# Altium schematic sheet main.SchDoc
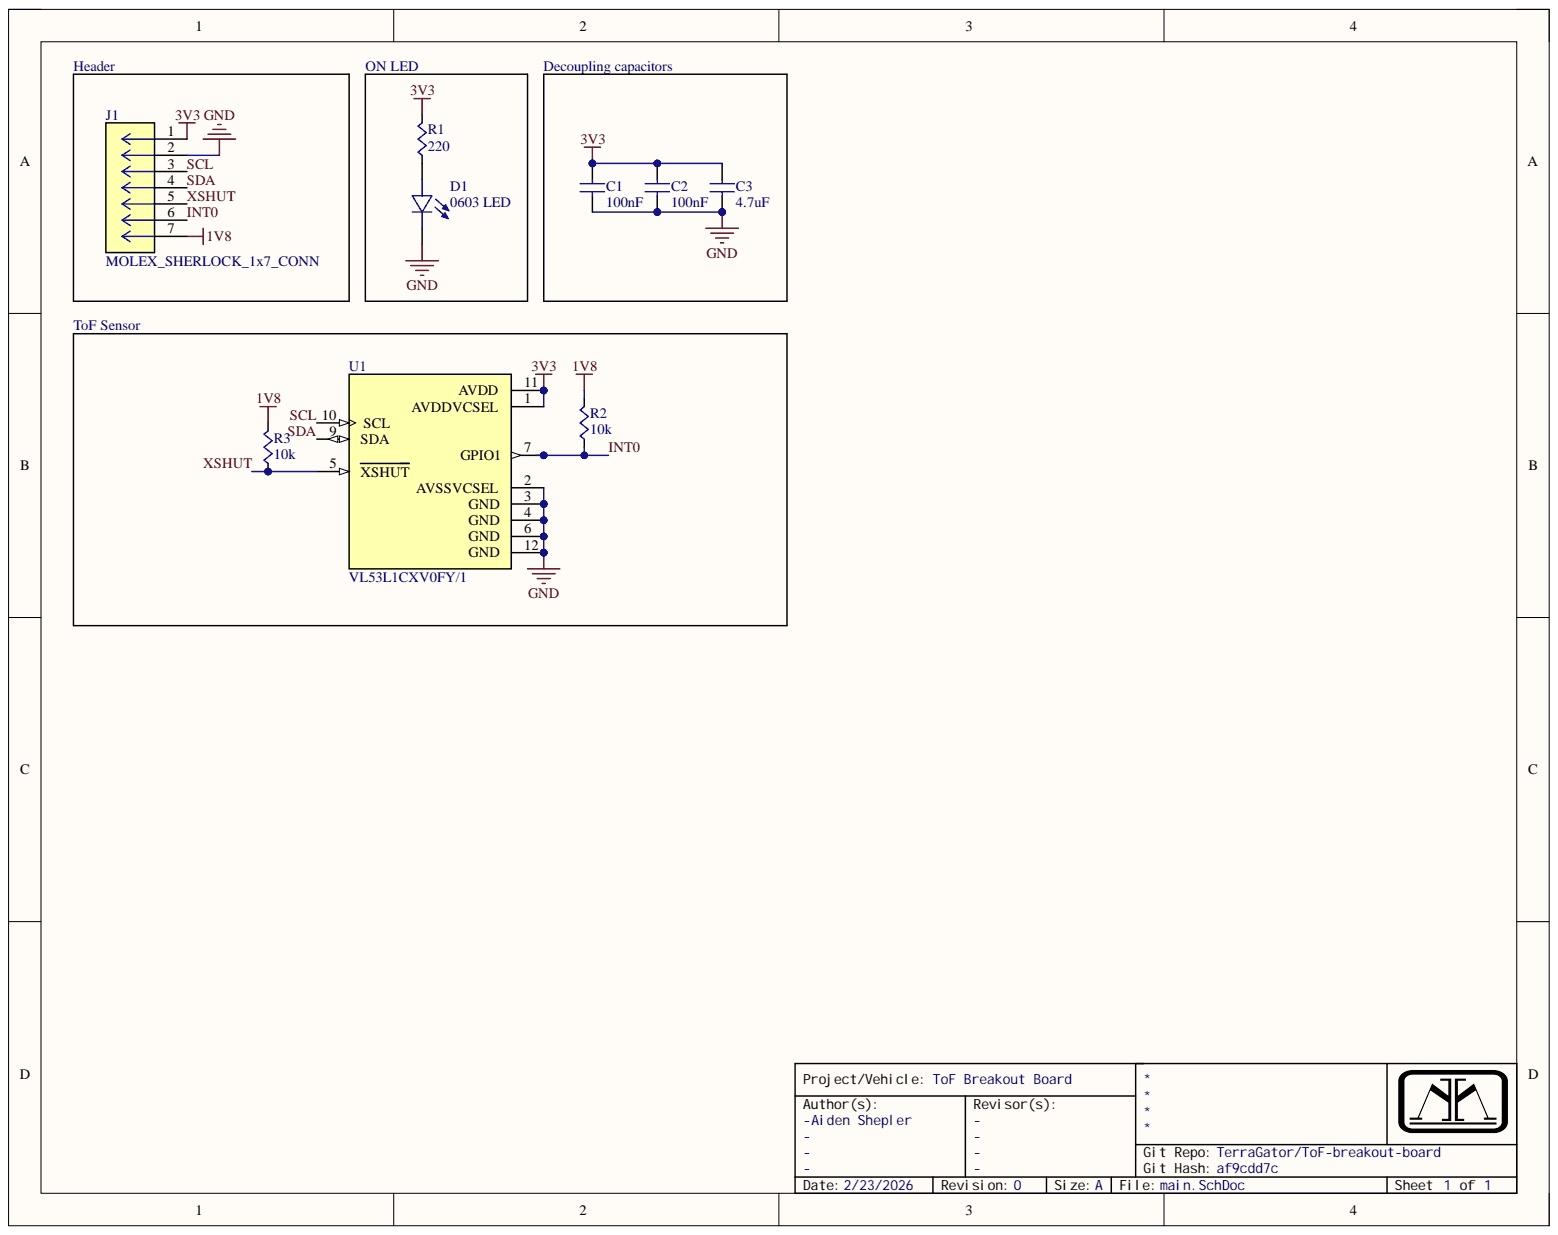
[diagram p0: main.SchDoc]

## Parts
C1  value 100nF  [CAPC0603]  (hidden comment: Cap)
C2  value 100nF  [CAPC0603]  (hidden comment: Cap)
C3  value 4.7uF  [CAPC0603]  (hidden comment: Cap)
D1  0603 LED  [0603 (LED)]
J1  MOLEX_SHERLOCK_1x7_CONN  [CON_353620750]
R1  value 220  [RES0603]  (hidden comment: Res)
R2  value 10k  [RES0603]  (hidden comment: Res)
R3  value 10k  [RES0603]  (hidden comment: Res)
U1  VL53L1CXV0FY/1  [LGA-12 (VL53L1CXV0FY/1)]

## Nets
1V8: J1.7 R2.1 R3.1
3V3: C1.1 C2.1 C3.1 J1.1 R1.1 U1.1(AVDDVCSEL) U1.11(AVDD)
GND: C1.2 C2.2 C3.2 D1.C J1.2 U1.2(AVSSVCSEL) U1.3(GND) U1.4(GND) U1.6(GND) U1.12(GND)
INT0: J1.6 R2.2 U1.7(GPIO1)
SCL: J1.3 U1.10(SCL)
SDA: J1.4 U1.9(SDA)
XSHUT: J1.5 R3.2 U1.5(XSHUT)
NetD1_A: D1.A R1.2

## Library data of the parts
D1 = 0603 LED: 150060SS75000 | LED Indication - Discrete 1.9V 0603 (1608 Metric); Component Kind: Standard; Library Name: Alexandria.IntLib
J1 = MOLEX_SHERLOCK_1x7_CONN: 1x7 2mm pitch sherlock connector; MP: 353620750; Availability: Unavailable; Component Kind: Standard; Library Name: 353620750.IntLib; Package: None; Price: None
R1-R3 = Res: SMD, RES0603; Component Kind: Standard; Library Name: Passives.SchLib; Supplier 1: Digi-Key; Supplier Part Number 1: RMCF0603JT10K0CT-ND
U1 = VL53L1CXV0FY/1: ToF Optical Sensor 157.480 (4m) I²C Output from STMelectronics; MF: STMicroelectronics; Availability: In Stock; Check_prices: https://www.snapeda.com/parts/VL53L1CXV0FY/1/STMicroelectronics/view-part/?ref=eda; Component Kind: Standard; MP: VL53L1CXV0FY/1; Package: LGA-12 STMicroelectronics; Price: None; Purchase-URL: https://pricing.snapeda.com/search/part/VL53L1CXV0FY/1/?ref=eda; SnapEDA_Link: https://www.snapeda.com/parts/VL53L1CXV0FY/1/STMicroelectronics/view-part/?ref=snap; Library Name: Alexandria.IntLib
C1-C3 = Cap: Component Kind: Standard; Library Name: Passives.SchLib; Supplier 1: Digi-Key; Supplier Part Number 1: 1276-1000-1-ND
